# Altium schematic sheet Microcontroller.SchDoc(Microcontroller)
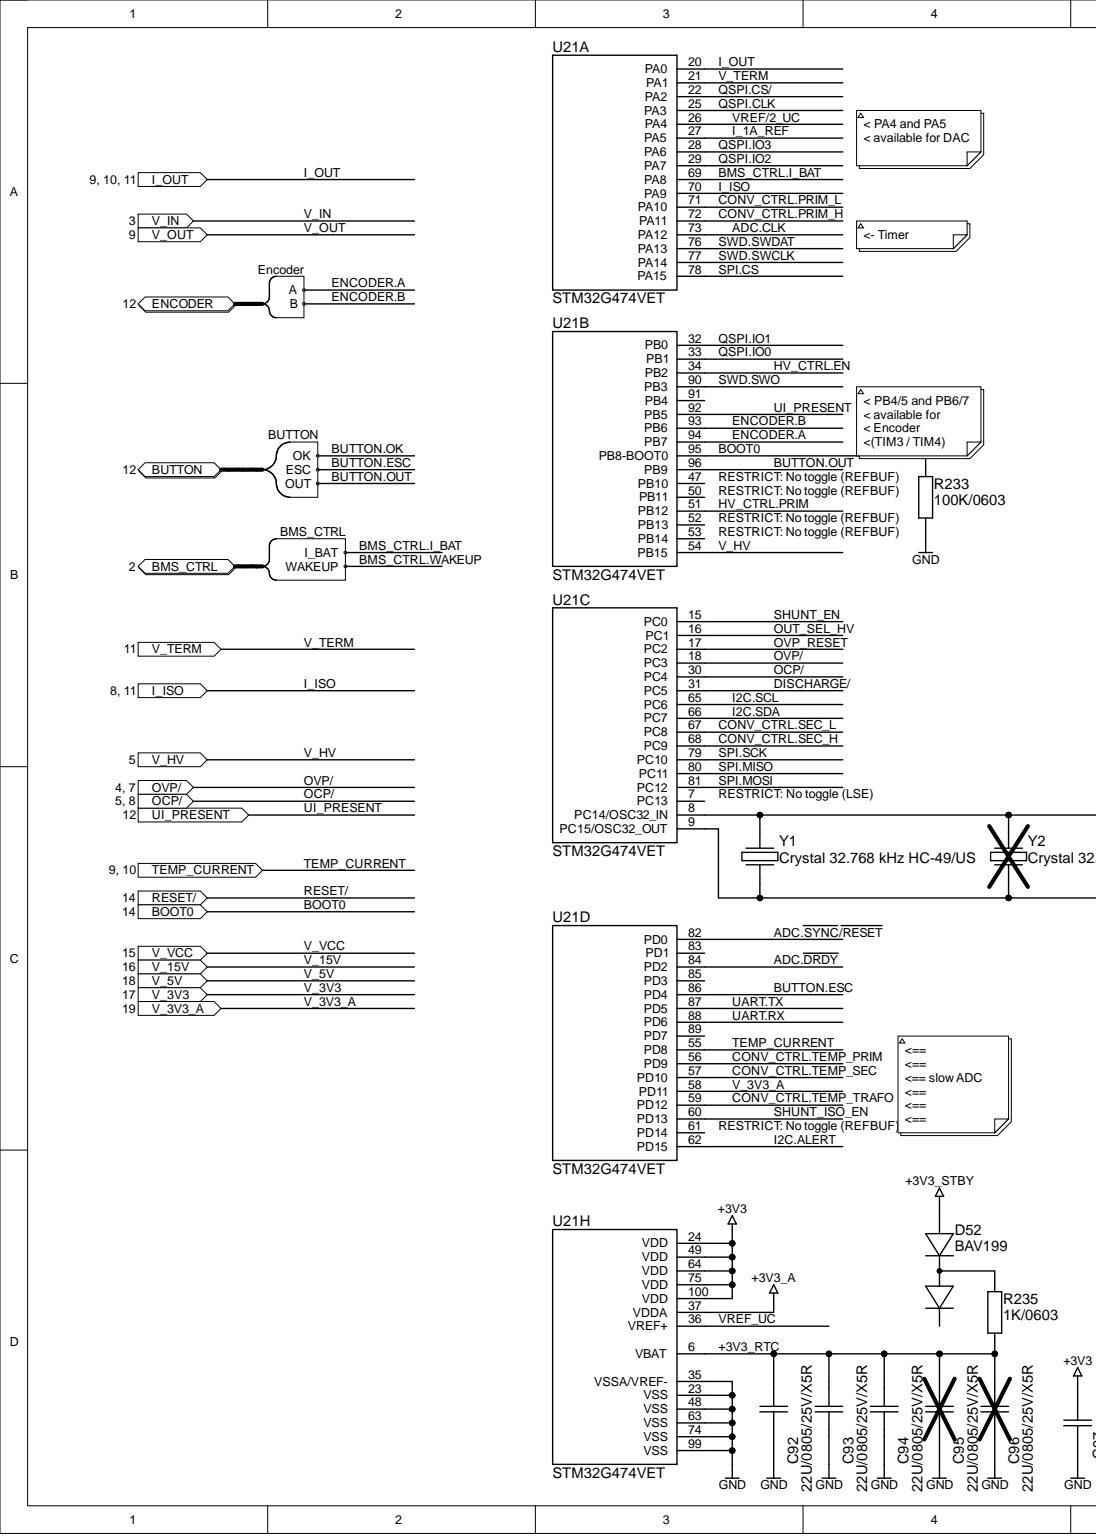
[diagram p0: Microcontroller.SchDoc, left]
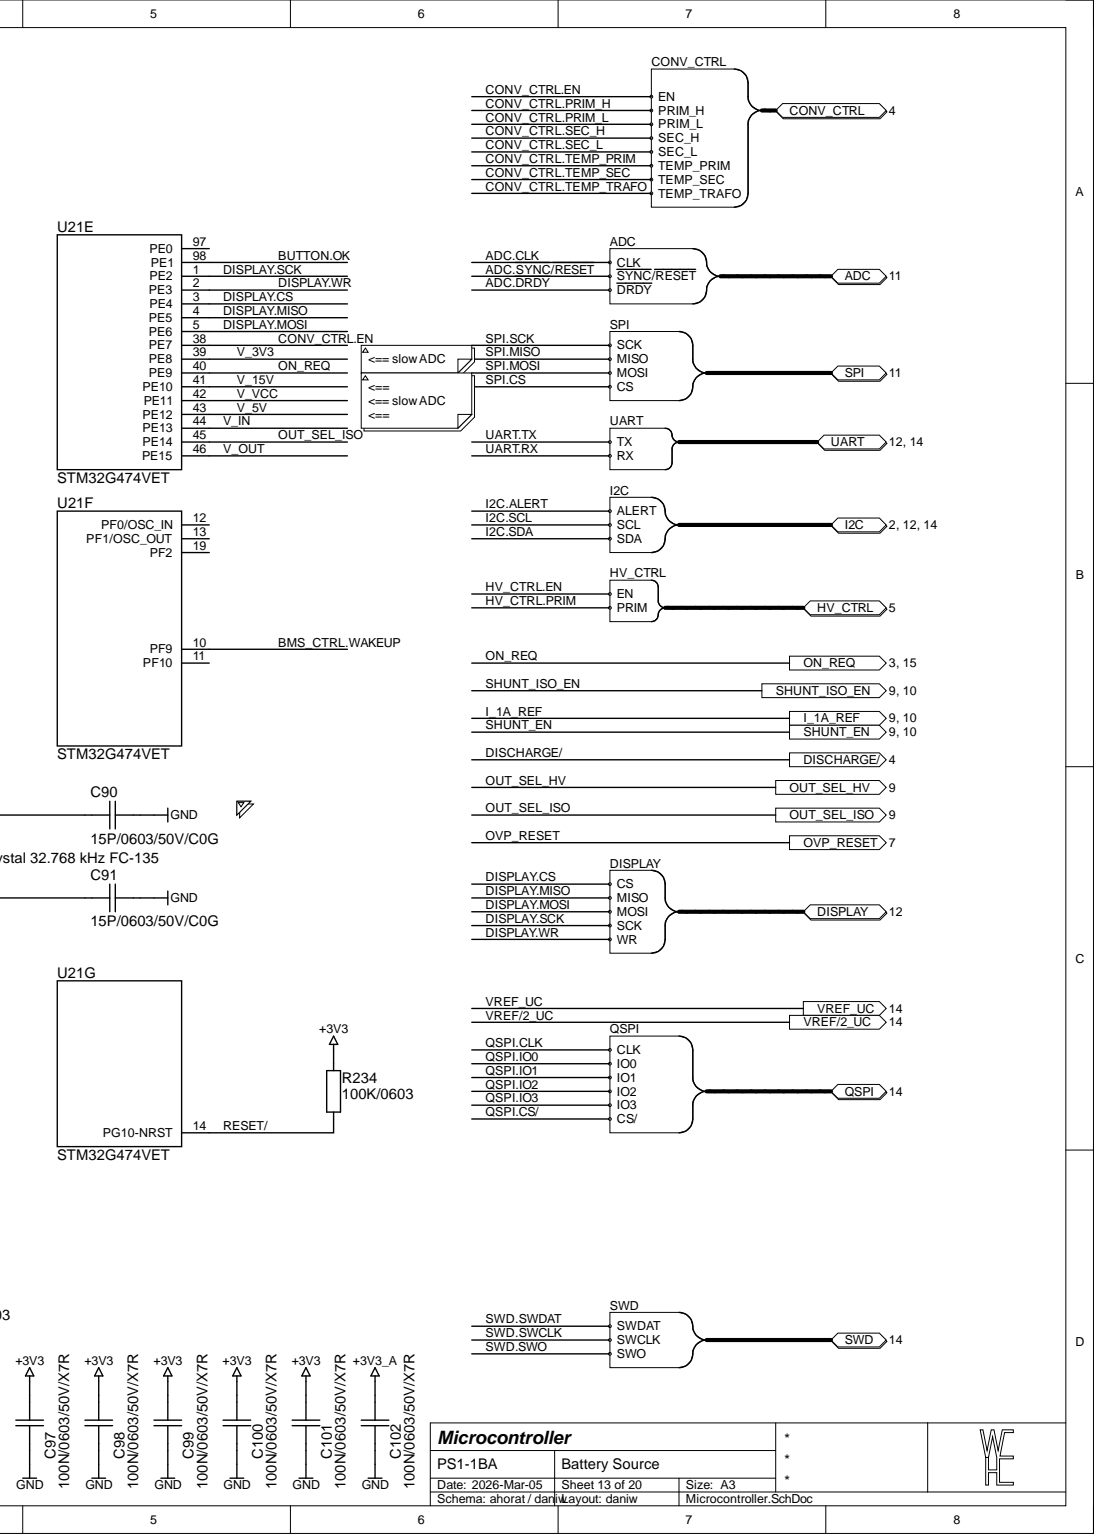
[diagram p1: Microcontroller.SchDoc, right]

## Parts
C90  15P/0603/50V/C0G  [CAP0603_C0G]
C91  15P/0603/50V/C0G  [CAP0603_C0G]
C92  22U/0805/25V/X5R  [CAP0805_X7R]
C93  22U/0805/25V/X5R  [CAP0805_X7R]
C94  22U/0805/25V/X5R  [CAP0805_X7R]
C95  22U/0805/25V/X5R  [CAP0805_X7R]
C96  22U/0805/25V/X5R  [CAP0805_X7R]
C97  100N/0603/50V/X7R  [CAP0603_X7R]
C98  100N/0603/50V/X7R  [CAP0603_X7R]
C99  100N/0603/50V/X7R  [CAP0603_X7R]
C100  100N/0603/50V/X7R  [CAP0603_X7R]
C101  100N/0603/50V/X7R  [CAP0603_X7R]
C102  100N/0603/50V/X7R  [CAP0603_X7R]
D52  BAV199  [SOT2395P280X125-3-SE]
R233  100K/0603  [R0603-0.40-WW]
R234  100K/0603  [R0603-0.40-WW]
R235  1K/0603  [R0603-0.40-WW]
U21  STM32G474VET  [QFP050P1600X1600X160-100]  (parts A,B,C,D,E,F,G,H)
Y1  Crystal 32.768 kHz HC-49/US  [CYSMD275P760X320C230]
Y2  Crystal 32.768 kHz FC-135  [CYSMD250P320X150X090]

## Ports
ADC, ADC.CLK, ADC.~{DRDY}, ADC.~{SYNC}/~{RESET}, BMS_CTRL, BMS_CTRL.I_BAT, BMS_CTRL.WAKEUP, BOOT0, BUTTON, BUTTON.ESC, BUTTON.OK, BUTTON.OUT, CONV_CTRL, CONV_CTRL.EN, CONV_CTRL.PRIM_H, CONV_CTRL.PRIM_L, CONV_CTRL.SEC_H, CONV_CTRL.SEC_L, CONV_CTRL.TEMP_PRIM, CONV_CTRL.TEMP_SEC, CONV_CTRL.TEMP_TRAFO, DISCHARGE/, DISPLAY, DISPLAY.CS, DISPLAY.MISO, DISPLAY.MOSI, DISPLAY.SCK, DISPLAY.WR, ENCODER, ENCODER.A, ENCODER.B, HV_CTRL, HV_CTRL.EN, HV_CTRL.PRIM, I2C, I2C.ALERT, I2C.SCL, I2C.SDA, I_1A_REF, I_ISO, I_OUT, OCP/, ON_REQ, OUT_SEL_HV, OUT_SEL_ISO, OVP/, OVP_RESET, QSPI, QSPI.CLK, QSPI.CS/, QSPI.IO0, QSPI.IO1, QSPI.IO2, QSPI.IO3, RESET/, SHUNT_EN, SHUNT_ISO_EN, SPI, SPI.CS, SPI.MISO, SPI.MOSI, SPI.SCK, SWD, SWD.SWCLK, SWD.SWDAT, SWD.SWO, TEMP_CURRENT, UART, UART.RX, UART.TX, UI_PRESENT, V_3V3, V_3V3_A, V_5V, V_15V, V_HV, V_IN, V_OUT, V_TERM, V_VCC, VREF/2_UC, VREF_UC

## Nets
+3V3: C97.1 C98.1 C99.1 C100.1 C101.1 R234.1 U21.24(VDD) U21.49(VDD) U21.64(VDD) U21.75(VDD) U21.100(VDD)
+3V3_A: C102.1 U21.37(VDDA)
+3V3_STBY: D52.1
GND: C90.2 C91.2 C92.2 C93.2 C94.2 C95.2 C96.2 C97.2 C98.2 C99.2 C100.2 C101.2 C102.2 R233.2 U21.23(VSS) U21.35(VSSA/VREF-) U21.48(VSS) U21.63(VSS) U21.74(VSS) U21.99(VSS)
+3V3_RTC: C92.1 C93.1 C94.1 C95.1 C96.1 R235.2 U21.6(VBAT)
ADC.CLK: U21.73(PA12) ; port ADC
ADC.~{DRDY}: U21.84(PD2) ; port ADC
ADC.~{SYNC}/~{RESET}: U21.82(PD0) ; port ADC
BMS_CTRL.I_BAT: U21.69(PA8) ; port BMS_CTRL
BMS_CTRL.WAKEUP: U21.10(PF9) ; port BMS_CTRL
BOOT0: R233.1 U21.95(PB8-BOOT0) ; port BOOT0
BUTTON.ESC: U21.86(PD4) ; port BUTTON
BUTTON.OK: U21.98(PE1) ; port BUTTON
BUTTON.OUT: U21.96(PB9) ; port BUTTON
CONV_CTRL.EN: U21.38(PE7) ; port CONV_CTRL
CONV_CTRL.PRIM_H: U21.72(PA11) ; port CONV_CTRL
CONV_CTRL.PRIM_L: U21.71(PA10) ; port CONV_CTRL
CONV_CTRL.SEC_H: U21.68(PC9) ; port CONV_CTRL
CONV_CTRL.SEC_L: U21.67(PC8) ; port CONV_CTRL
CONV_CTRL.TEMP_PRIM: U21.56(PD9) ; port CONV_CTRL
CONV_CTRL.TEMP_SEC: U21.57(PD10) ; port CONV_CTRL
CONV_CTRL.TEMP_TRAFO: U21.59(PD12) ; port CONV_CTRL
DISCHARGE/: U21.31(PC5) ; port DISCHARGE/
DISPLAY.CS: U21.3(PE4) ; port DISPLAY
DISPLAY.MISO: U21.4(PE5) ; port DISPLAY
DISPLAY.MOSI: U21.5(PE6) ; port DISPLAY
DISPLAY.SCK: U21.1(PE2) ; port DISPLAY
DISPLAY.WR: U21.2(PE3) ; port DISPLAY
ENCODER.A: U21.94(PB7) ; port ENCODER
ENCODER.B: U21.93(PB6) ; port ENCODER
HV_CTRL.EN: U21.34(PB2) ; port HV_CTRL
HV_CTRL.PRIM: U21.51(PB12) ; port HV_CTRL
I2C.ALERT: U21.62(PD15) ; port I2C
I2C.SCL: U21.65(PC6) ; port I2C
I2C.SDA: U21.66(PC7) ; port I2C
I_1A_REF: U21.27(PA5) ; port I_1A_REF
I_ISO: U21.70(PA9) ; port I_ISO
I_OUT: U21.20(PA0) ; port I_OUT
OCP/: U21.30(PC4) ; port OCP/
ON_REQ: U21.40(PE9) ; port ON_REQ
OUT_SEL_HV: U21.16(PC1) ; port OUT_SEL_HV
OUT_SEL_ISO: U21.45(PE14) ; port OUT_SEL_ISO
OVP/: U21.18(PC3) ; port OVP/
OVP_RESET: U21.17(PC2) ; port OVP_RESET
QSPI.CLK: U21.25(PA3) ; port QSPI
QSPI.CS/: U21.22(PA2) ; port QSPI
QSPI.IO0: U21.33(PB1) ; port QSPI
QSPI.IO1: U21.32(PB0) ; port QSPI
QSPI.IO2: U21.29(PA7) ; port QSPI
QSPI.IO3: U21.28(PA6) ; port QSPI
RESET/: R234.2 U21.14(PG10-NRST) ; port RESET/
SHUNT_EN: U21.15(PC0) ; port SHUNT_EN
SHUNT_ISO_EN: U21.60(PD13) ; port SHUNT_ISO_EN
SPI.CS: U21.78(PA15) ; port SPI
SPI.MISO: U21.80(PC11) ; port SPI
SPI.MOSI: U21.81(PC12) ; port SPI
SPI.SCK: U21.79(PC10) ; port SPI
SWD.SWCLK: U21.77(PA14) ; port SWD
SWD.SWDAT: U21.76(PA13) ; port SWD
SWD.SWO: U21.90(PB3) ; port SWD
TEMP_CURRENT: U21.55(PD8) ; port TEMP_CURRENT
UART.RX: U21.88(PD6) ; port UART
UART.TX: U21.87(PD5) ; port UART
UI_PRESENT: U21.92(PB5) ; port UI_PRESENT
V_3V3: U21.39(PE8) ; port V_3V3
V_3V3_A: U21.58(PD11) ; port V_3V3_A
V_5V: U21.43(PE12) ; port V_5V
V_15V: U21.41(PE10) ; port V_15V
V_HV: U21.54(PB15) ; port V_HV
V_IN: U21.44(PE13) ; port V_IN
V_OUT: U21.46(PE15) ; port V_OUT
V_TERM: U21.21(PA1) ; port V_TERM
V_VCC: U21.42(PE11) ; port V_VCC
VREF/2_UC: U21.26(PA4) ; port VREF/2_UC
VREF_UC: U21.36(VREF+) ; port VREF_UC
NetC90_1: C90.1 U21.8(PC14/OSC32_IN) Y1.1 Y2.1
NetC91_1: C91.1 U21.9(PC15/OSC32_OUT) Y1.2 Y2.2
NetD52_2: D52.2
NetD52_3: D52.3 R235.1
NetU21_7: U21.7(PC13)
NetU21_11: U21.11(PF10)
NetU21_12: U21.12(PF0/OSC_IN)
NetU21_13: U21.13(PF1/OSC_OUT)
NetU21_19: U21.19(PF2)
NetU21_47: U21.47(PB10)
NetU21_50: U21.50(PB11)
NetU21_52: U21.52(PB13)
NetU21_53: U21.53(PB14)
NetU21_61: U21.61(PD14)
NetU21_83: U21.83(PD1)
NetU21_85: U21.85(PD3)
NetU21_89: U21.89(PD7)
NetU21_91: U21.91(PB4)
NetU21_97: U21.97(PE0)

## Library data of the parts
C90 C91 = 15P/0603/50V/C0G: CAP SM 0603 15pF 50V C0G; Manufacturer: GENERIC; Manufacturer 1: YAGEO; Manufacturer Part Number 1: CC0603JRNPO9BN150; Absolute Value: 1.5E-11; Database Table Name: C$; Eurocircuits GPN: GPC0603150-C0G; Component Kind: Standard; HelpURL: https://www.yageo.com/upload/media/product/productsearch/datasheet/mlcc/UPY-GP_NP0_16V-to-50V_18.pdf; JLC Type: Basic; Manufacturer 2: YAGEO; Manufacturer Part Number 2: CC0603KRNPO9BN150; Manufacturer Part Number 3: Samsung Electro-Mechanics; Package: 0603; Part Number: 15P/0603/50V/C0G; Supplier 1: Digi-Key; Supplier 2: Digi-Key; Supplier 3: LCSC; Supplier Part Number 1: 311-1060-1-ND; Supplier Part Number 2: 311-4028-1-ND; Supplier Part Number 3: C1644; Value: 15pF; Library Name: daniw_altium_lib.DbLib
C92-C96 = 22U/0805/25V/X5R: CAP SM 0805 22uF 25V X5R; Manufacturer: GENERIC; Manufacturer 1: Samsung Electro-Mechanics; Manufacturer Part Number 1: CL21A226MAYNNNE; Absolute Value: 2.2E-5; Database Table Name: C$; Eurocircuits GPN: GPN0805106-25; Component Kind: Standard; HelpURL: https://mm.digikey.com/Volume0/opasdata/d220001/medias/docus/339/CL21A226MAYNNNE_Spec.pdf; JLC Type: Basic; Manufacturer Part Number 3: CL21A226MAQNNNE; Package: 0805; Part Number: 22U/0805/25V/X5R; Supplier 1: Digi-Key; Supplier 3: LCSC; Supplier Part Number 1: 1276-CL21A226MAYNNNECT-ND; Supplier Part Number 3: C45783; Value: 22uF; Library Name: daniw_altium_lib.DbLib
C97-C102 = 100N/0603/50V/X7R: CAP SM 0603 100nF 50V X7R; Manufacturer: GENERIC; Manufacturer 1: YAGEO; Manufacturer Part Number 1: CC0603KPX7R9BB104; Absolute Value: 1E-7; Database Table Name: C$; Eurocircuits GPN: GPC0603104; Component Kind: Standard; HelpURL: https://www.yageo.com/upload/media/product/app/datasheet/mlcc/upy-gphc_x7r_6_3v-to-250v.pdf; JLC Type: Basic; Manufacturer 2: YAGEO; Manufacturer Part Number 2: CC0603MRX7R9BB104; Manufacturer Part Number 3: CC0603KRX7R9BB104; Package: 0603; Part Number: 100N/0603/50V/X7R; Supplier 1: Digi-Key; Supplier 2: Digi-Key; Supplier 3: LCSC; Supplier Part Number 1: 311-3567-1-ND; Supplier Part Number 2: 311-4110-1-ND; Supplier Part Number 3: C14663; Value: 100nF; Library Name: daniw_altium_lib.DbLib
D52 = BAV199: Silicon Low Leakage Diode 85V; Manufacturer: Infineon Technologies; Manufacturer Part Number 1: BAV199E6433HTMA1; Database Table Name: D$; Absolute Value: 85V; Component Kind: Standard; HelpURL: https://www.infineon.com/dgdl/bav199series.pdf?folderId=db3a30431400ef6801141c748874044e&fileId=db3a30431400ef6801141cba733104e5; Manufacturer 1: Infineon Technologies; Manufacturer 3: LRC; Manufacturer Part Number 3: LBAV199LT1G; Package: SOT23; Part Number: BAV199; Supplier 1: Digikey; Supplier 3: LCSC; Supplier Part Number 1: BAV199E6433HTMA1CT-ND; Supplier Part Number 3: C12740; Value: BAV199; Library Name: daniw_altium_lib.DbLib
R233 R234 = 100K/0603: RES SM 0603 100K; Manufacturer: GENERIC; Manufacturer 1: YAGEO; Manufacturer Part Number 1: RC0603FR-13100KL; Absolute Value: 100000; Database Table Name: R$; Eurocircuits GPN: GPR0603100K; Component Kind: Standard; HelpURL: https://www.yageogroup.com/content/datasheet/asset/file/PYU-RC_GROUP_51_ROHS_L; JLC Type: Basic; Manufacturer 2: YAGEO; Manufacturer 3: UNI-ROYAL(Uniroyal Elec); Manufacturer Part Number 2: RC0603FR-10100KL; Manufacturer Part Number 3: 0603WAF1003T5E; Package: 0603; Part Number: 100K/0603; Supplier 1: Digi-Key; Supplier 2: Digi-Key; Supplier 3: LCSC; Supplier Part Number 1: 13-RC0603FR-13100KLCT-ND; Supplier Part Number 2: 13-RC0603FR-10100KLCT-ND; Supplier Part Number 3: C25803; Value: 100K; Library Name: daniw_altium_lib.DbLib
R235 = 1K/0603: RES SM 0603 1K; Manufacturer: GENERIC; Manufacturer 1: YAGEO; Manufacturer Part Number 1: RC0603FR-131KL; Absolute Value: 1000; Database Table Name: R$; Eurocircuits GPN: GPR06031K; Component Kind: Standard; HelpURL: https://www.yageogroup.com/content/datasheet/asset/file/PYU-RC_GROUP_51_ROHS_L; JLC Type: Basic; Manufacturer 2: YAGEO; Manufacturer 3: UNI-ROYAL(Uniroyal Elec); Manufacturer Part Number 2: RC0603FR-101KL; Manufacturer Part Number 3: 0603WAF1001T5E; Package: 0603; Part Number: 1K/0603; Supplier 1: Digi-Key; Supplier 2: Digi-Key; Supplier 3: LCSC; Supplier Part Number 1: 13-RC0603FR-131KLCT-ND; Supplier Part Number 2: 13-RC0603FR-101KLCT-ND; Supplier Part Number 3: C21190; Value: 1K; Library Name: daniw_altium_lib.DbLib
U21 = STM32G474VET: STM32G474VET, Arm® Cortex®-M4 32-bit MCU+FPU, 170 MHz / 213 DMIPS, 512 kB flash, 128 KB SRAM, rich analog, math acc, 184 ps 12 chan Hi-res timer, QFP-100; Manufacturer: ST Microelectronics; Manufacturer 1: STMicroelectronics; Manufacturer Part Number 1: STM32G474VET6; Database Table Name: Integrated$; Component Kind: Standard; HelpURL: https://www.st.com/resource/en/datasheet/stm32g474ve.pdf; JLC Type: Extended; Manufacturer 2: STMicroelectronics; Manufacturer 3: STMicroelectronics; Manufacturer Part Number 2: STM32G474VET3; Manufacturer Part Number 3: STM32G474VET6TR; Package: QFP-100; Part Number: STM32G474VET; Supplier 1: Digi-Key; Supplier 2: Digi-Key; Supplier 3: LCSC; Supplier Part Number 1: 497-19476-ND; Supplier Part Number 2: 497-STM32G474VET3-ND; Supplier Part Number 3: C1857438; Library Name: daniw_altium_lib.DbLib
Y1 = Crystal 32.768 kHz HC-49/US: 32.768 kHz ±20ppm Crystal 12,5pF HC-49/US; Manufacturer: CJKJ; Database Table Name: Misc$; Component Kind: Standard; HelpURL: https://www.lcsc.com/datasheet/C1122370.pdf; JLC Type: Extended; Manufacturer 3: CJKJ; Manufacturer Part Number 3: C30732.768N12.5M20; Package: HC-49/US; Part Number: Crystal 32.768 kHz HC-49/US; Supplier 3: LCSC; Supplier Part Number 3: C1122370; Library Name: daniw_altium_lib.DbLib
Y2 = Crystal 32.768 kHz FC-135: 32.768 kHz ±20ppm Crystal 12,5pF FC-135; Manufacturer: Epson; Manufacturer Part Number 1: FC-135 32.7680KA-A5; Database Table Name: Misc$; Component Kind: Standard; HelpURL: https://support.epson.biz/td/api/doc_check.php?dl=brief_FC-135; JLC Type: Basic; Manufacturer 1: EPSON; Manufacturer 3: EPSON; Manufacturer Part Number 3: Q13FC13500004; Package: FC-135; Part Number: Crystal 32.768 kHz FC-135; Supplier 1: Digi-Key; Supplier 3: LCSC; Supplier Part Number 1: SER4103CT-ND; Supplier Part Number 3: C32346; Library Name: daniw_altium_lib.DbLib
